# Altium schematic sheet BME280.SchDoc
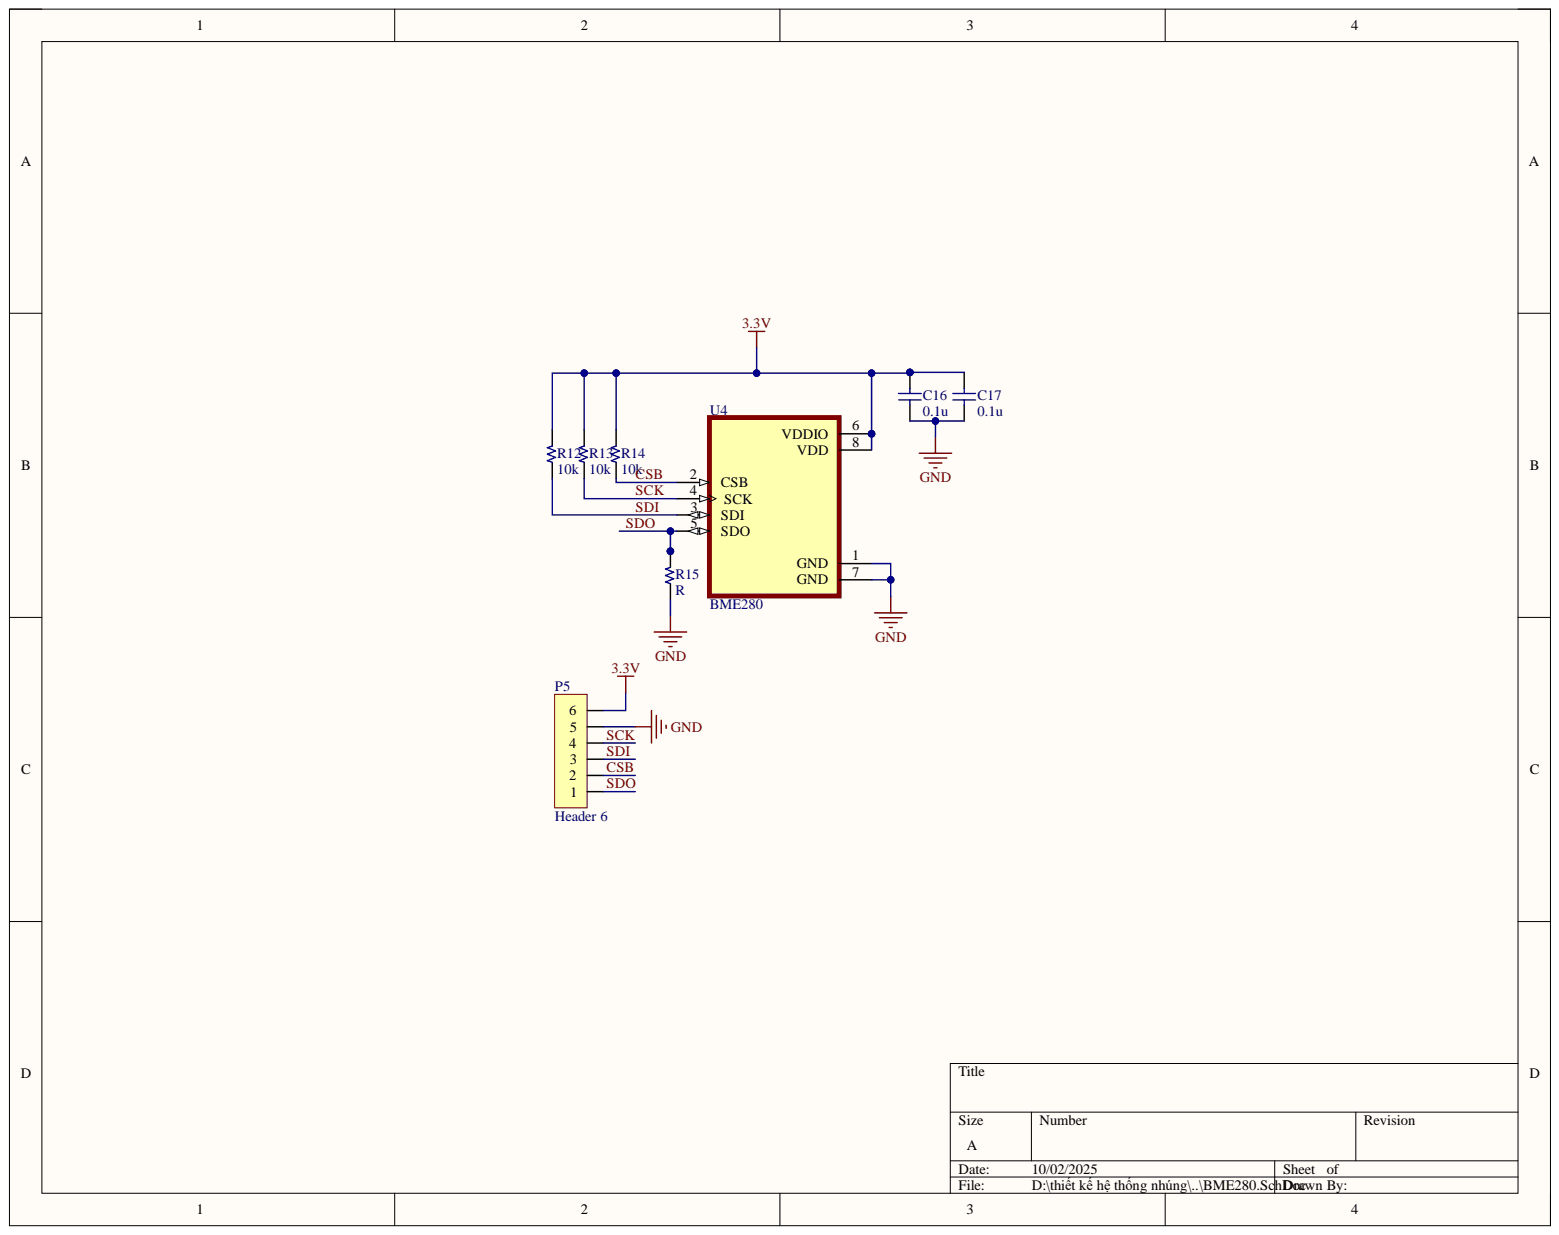
[diagram p0: BME280.SchDoc]

## Parts
C16  0.1u  [C 0603]
C17  0.1u  [C 0603]
P5  Header 6  [HDR/Header/6]
R12  10k  [R 0603]
R13  10k  [R 0603]
R14  10k  [R 0603]
R15  R  [R 0603]
U4  BME280  [PSON65P250X250X100-8N]

## Nets
3.3V: C16.2 C17.2 P5.6 R12.2 R13.2 R14.2 U4.6(VDDIO) U4.8(VDD)
GND: C16.1 C17.1 P5.5 R15.1 U4.1(GND) U4.7(GND)
CSB: P5.2 R14.1 U4.2(CSB)
SCK: P5.4 R13.1 U4.4(SCK)
SDI: P5.3 R12.1 U4.3(SDI)
SDO: P5.1 R15.2 U4.5(SDO)

## Library data of the parts
C16 C17 = Capacitor NP: Capacitor non-pol; Component Kind: Standard; Library Name: chipfc_libs.SchLib
P5 = Header 6: Header, 6-Pin; Component Kind: Standard; Library Name: connectors.SchLib
R12-R15 = Resistor: Component Kind: Standard; Description: Resistor; Library Name: chipfc_libs.SchLib
U4 = BME280: DIGITAL HUMIDITY, PRESSURE AND TEMPERATURE SENSOR<br>* Digital interface IÂ²C (up to 3.4 MHz) and SPI (3 and 4 wire, up to 10 MHz)* Supply voltage VDD main supply voltage range: 1.71 V to 3.6 V*VDDIO interface voltage range: 1.2 V to 3.6 V<br> Operating range -40â€|+85 Â°C, 0â€|100 % rel. humidity, 300â€|1100 hPa <br><br>  The library has been designed by<a href="https://www.facebook.com/groups/eaglecadsoftUK"> Richard Magdycz</a>; Availability: Unavailable; Component Kind: Standard; Library Name: BME280.IntLib; MP: BME280; Package: None; Price: None
